# Altium schematic sheet GA-RELE.SchDoc(GA-RELE)
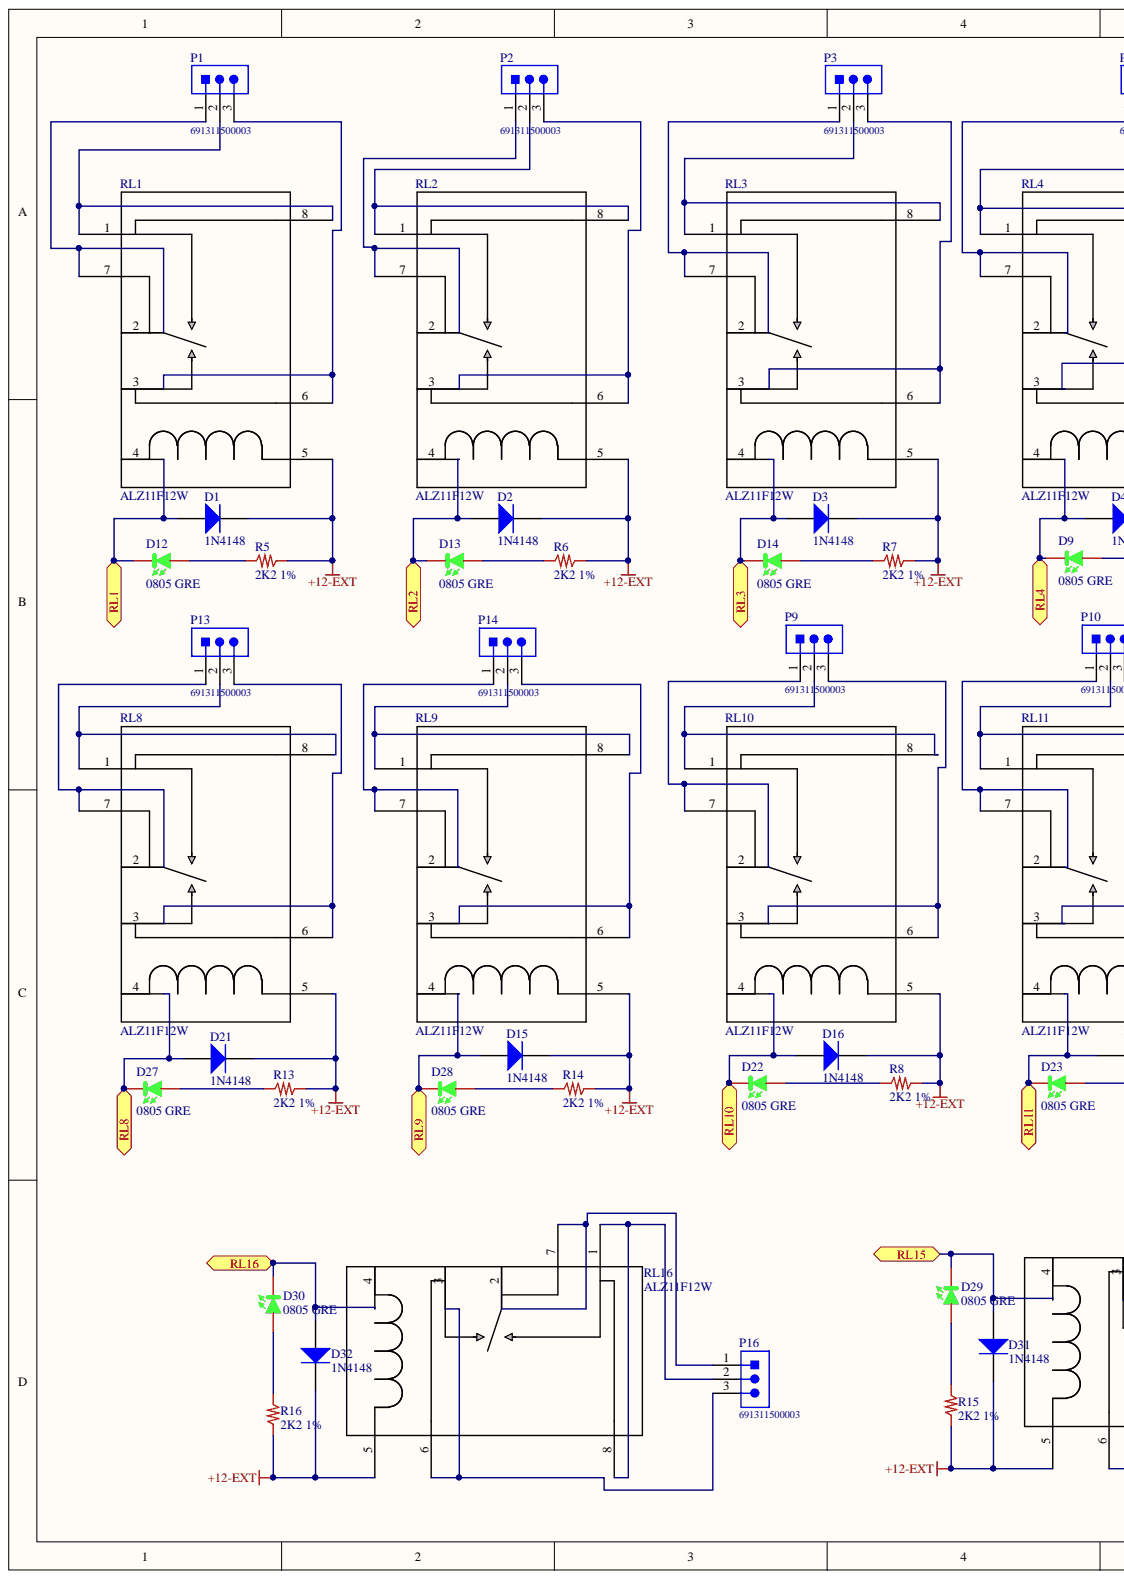
[diagram p0: GA-RELE.SchDoc, left]
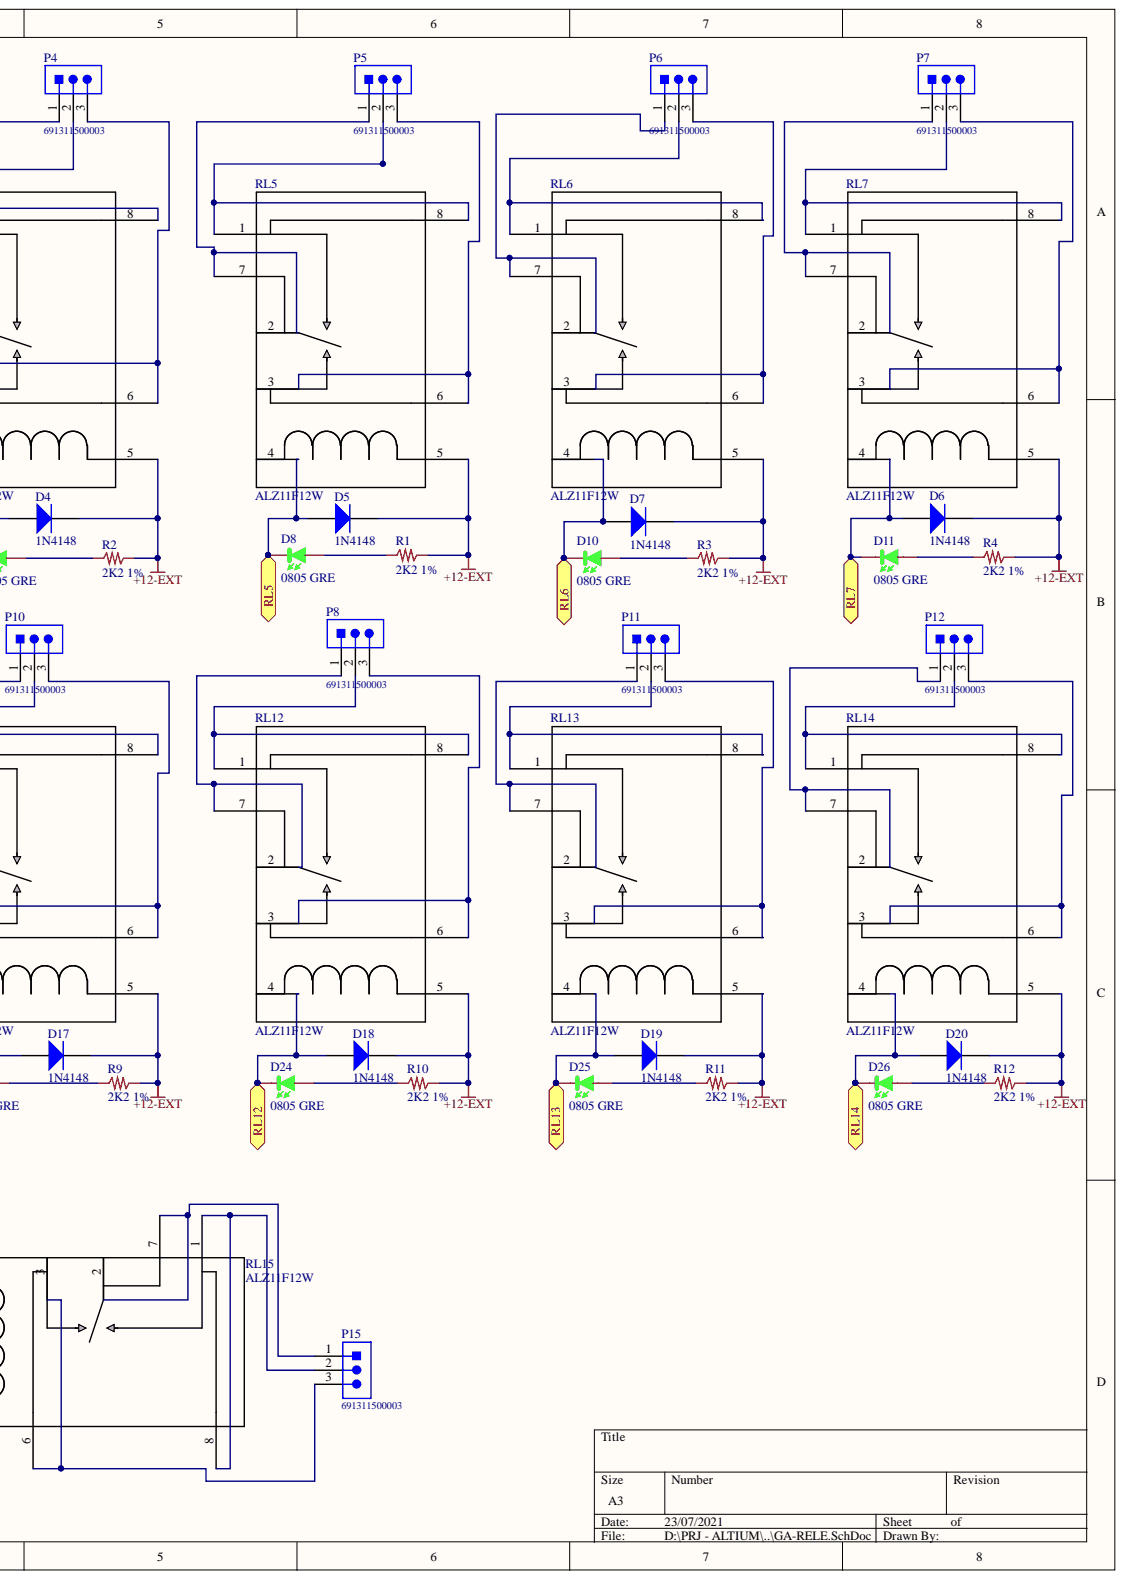
[diagram p1: GA-RELE.SchDoc, right]

## Parts
D1  1N4148  [1N4148]
D2  1N4148  [1N4148]
D3  1N4148  [1N4148]
D4  1N4148  [1N4148]
D5  1N4148  [1N4148]
D6  1N4148  [1N4148]
D7  1N4148  [1N4148]
D8  0805 GRE  [0805_Green]
D9  0805 GRE  [0805_Green]
D10  0805 GRE  [0805_Green]
D11  0805 GRE  [0805_Green]
D12  0805 GRE  [0805_Green]
D13  0805 GRE  [0805_Green]
D14  0805 GRE  [0805_Green]
D15  1N4148  [1N4148]
D16  1N4148  [1N4148]
D17  1N4148  [1N4148]
D18  1N4148  [1N4148]
D19  1N4148  [1N4148]
D20  1N4148  [1N4148]
D21  1N4148  [1N4148]
D22  0805 GRE  [0805_Green]
D23  0805 GRE  [0805_Green]
D24  0805 GRE  [0805_Green]
D25  0805 GRE  [0805_Green]
D26  0805 GRE  [0805_Green]
D27  0805 GRE  [0805_Green]
D28  0805 GRE  [0805_Green]
D29  0805 GRE  [0805_Green]
D30  0805 GRE  [0805_Green]
D31  1N4148  [1N4148]
D32  1N4148  [1N4148]
P1  691311500003  [691311500003]
P2  691311500003  [691311500003]
P3  691311500003  [691311500003]
P4  691311500003  [691311500003]
P5  691311500003  [691311500003]
P6  691311500003  [691311500003]
P7  691311500003  [691311500003]
P8  691311500003  [691311500003]
P9  691311500003  [691311500003]
P10  691311500003  [691311500003]
P11  691311500003  [691311500003]
P12  691311500003  [691311500003]
P13  691311500003  [691311500003]
P14  691311500003  [691311500003]
P15  691311500003  [691311500003]
P16  691311500003  [691311500003]
R1  2K2 1%  [0805_R]
R2  2K2 1%  [0805_R]
R3  2K2 1%  [0805_R]
R4  2K2 1%  [0805_R]
R5  2K2 1%  [0805_R]
R6  2K2 1%  [0805_R]
R7  2K2 1%  [0805_R]
R8  2K2 1%  [0805_R]
R9  2K2 1%  [0805_R]
R10  2K2 1%  [0805_R]
R11  2K2 1%  [0805_R]
R12  2K2 1%  [0805_R]
R13  2K2 1%  [0805_R]
R14  2K2 1%  [0805_R]
R15  2K2 1%  [0805_R]
R16  2K2 1%  [0805_R]
RL1  ALZ11F12W  [PAN_ALZ1]
RL2  ALZ11F12W  [PAN_ALZ1]
RL3  ALZ11F12W  [PAN_ALZ1]
RL4  ALZ11F12W  [PAN_ALZ1]
RL5  ALZ11F12W  [PAN_ALZ1]
RL6  ALZ11F12W  [PAN_ALZ1]
RL7  ALZ11F12W  [PAN_ALZ1]
RL8  ALZ11F12W  [PAN_ALZ1]
RL9  ALZ11F12W  [PAN_ALZ1]
RL10  ALZ11F12W  [PAN_ALZ1]
RL11  ALZ11F12W  [PAN_ALZ1]
RL12  ALZ11F12W  [PAN_ALZ1]
RL13  ALZ11F12W  [PAN_ALZ1]
RL14  ALZ11F12W  [PAN_ALZ1]
RL15  ALZ11F12W  [PAN_ALZ1]
RL16  ALZ11F12W  [PAN_ALZ1]

## Ports
RL1, RL2, RL3, RL4, RL5, RL6, RL7, RL8, RL9, RL10, RL11, RL12, RL13, RL14, RL15, RL16

## Nets
+12-EXT: D1.2 D2.2 D3.2 D4.2 D5.2 D6.2 D7.2 D15.2 D16.2 D17.2 D18.2 D19.2 D20.2 D21.2 D31.2 D32.2 R1.2 R2.2 R3.2 R4.2 R5.2 R6.2 R7.2 R8.2 R9.2 R10.2 R11.2 R12.2 R13.2 R14.2 R15.2 R16.2 RL1.5 RL2.5 RL3.5 RL4.5 RL5.5 RL6.5 RL7.5 RL8.5 RL9.5 RL10.5 RL11.5 RL12.5 RL13.5 RL14.5 RL15.5 RL16.5
RL1: D1.1 D12.K RL1.4 ; port RL1
RL2: D2.1 D13.K RL2.4 ; port RL2
RL3: D3.1 D14.K RL3.4 ; port RL3
RL4: D4.1 D9.K RL4.4 ; port RL4
RL5: D5.1 D8.K RL5.4 ; port RL5
RL6: D7.1 D10.K RL6.4 ; port RL6
RL7: D6.1 D11.K RL7.4 ; port RL7
RL8: D21.1 D27.K RL8.4 ; port RL8
RL9: D15.1 D28.K RL9.4 ; port RL9
RL10: D16.1 D22.K RL10.4 ; port RL10
RL11: D17.1 D23.K RL11.4 ; port RL11
RL12: D18.1 D24.K RL12.4 ; port RL12
RL13: D19.1 D25.K RL13.4 ; port RL13
RL14: D20.1 D26.K RL14.4 ; port RL14
RL15: D29.K D31.1 RL15.4 ; port RL15
RL16: D30.K D32.1 RL16.4 ; port RL16
NetD8_A: D8.A R1.1
NetD9_A: D9.A R2.1
NetD10_A: D10.A R3.1
NetD11_A: D11.A R4.1
NetD12_A: D12.A R5.1
NetD13_A: D13.A R6.1
NetD14_A: D14.A R7.1
NetD22_A: D22.A R8.1
NetD23_A: D23.A R9.1
NetD24_A: D24.A R10.1
NetD25_A: D25.A R11.1
NetD26_A: D26.A R12.1
NetD27_A: D27.A R13.1
NetD28_A: D28.A R14.1
NetD29_A: D29.A R15.1
NetD30_A: D30.A R16.1
NetP1_1: P1.1 RL1.2 RL1.7
NetP1_2: P1.2 RL1.1 RL1.8
NetP1_3: P1.3 RL1.3 RL1.6
NetP2_1: P2.1 RL2.2 RL2.7
NetP2_2: P2.2 RL2.1 RL2.8
NetP2_3: P2.3 RL2.3 RL2.6
NetP3_1: P3.1 RL3.2 RL3.7
NetP3_2: P3.2 RL3.1 RL3.8
NetP3_3: P3.3 RL3.3 RL3.6
NetP4_1: P4.1 RL4.2 RL4.7
NetP4_2: P4.2 RL4.1 RL4.8
NetP4_3: P4.3 RL4.3 RL4.6
NetP5_1: P5.1 RL5.2 RL5.7
NetP5_2: P5.2 RL5.1 RL5.8
NetP5_3: P5.3 RL5.3 RL5.6
NetP6_1: P6.1 RL6.2 RL6.7
NetP6_2: P6.2 RL6.1 RL6.8
NetP6_3: P6.3 RL6.3 RL6.6
NetP7_1: P7.1 RL7.2 RL7.7
NetP7_2: P7.2 RL7.1 RL7.8
NetP7_3: P7.3 RL7.3 RL7.6
NetP8_1: P8.1 RL12.2 RL12.7
NetP8_2: P8.2 RL12.1 RL12.8
NetP8_3: P8.3 RL12.3 RL12.6
NetP9_1: P9.1 RL10.2 RL10.7
NetP9_2: P9.2 RL10.1 RL10.8
NetP9_3: P9.3 RL10.3 RL10.6
NetP10_1: P10.1 RL11.2 RL11.7
NetP10_2: P10.2 RL11.1 RL11.8
NetP10_3: P10.3 RL11.3 RL11.6
NetP11_1: P11.1 RL13.2 RL13.7
NetP11_2: P11.2 RL13.1 RL13.8
NetP11_3: P11.3 RL13.3 RL13.6
NetP12_1: P12.1 RL14.2 RL14.7
NetP12_2: P12.2 RL14.1 RL14.8
NetP12_3: P12.3 RL14.3 RL14.6
NetP13_1: P13.1 RL8.2 RL8.7
NetP13_2: P13.2 RL8.1 RL8.8
NetP13_3: P13.3 RL8.3 RL8.6
NetP14_1: P14.1 RL9.2 RL9.7
NetP14_2: P14.2 RL9.1 RL9.8
NetP14_3: P14.3 RL9.3 RL9.6
NetP15_1: P15.1 RL15.2 RL15.7
NetP15_2: P15.2 RL15.1 RL15.8
NetP15_3: P15.3 RL15.3 RL15.6
NetP16_1: P16.1 RL16.2 RL16.7
NetP16_2: P16.2 RL16.1 RL16.8
NetP16_3: P16.3 RL16.3 RL16.6

## Library data of the parts
D1-D7 D15-D21 D31 D32 = 1N4148: High Conductance Fast Diode; Published: 8-Jun-2000; PackageReference: DO-35; PackageDescription: Diode, Axial; Body 3.8 x 1.9 mm (LxDia. max), Lead Dia. 0.53 mm (max); LatestRevisionNote: Re-released for DXP Platform.; LatestRevisionDate: 17-Jul-2002; DatasheetVersion: 1997; ComponentLink2URL: http://www.fairchildsemi.com/ds/1N%2F1N4148.pdf; ComponentLink2Description: Datasheet; ComponentLink1URL: http://www.fairchildsemi.com/; ComponentLink1Description: Manufacturer Link; Component Kind: Standard; Code_JEDEC: DO-204-AH; Publisher: Altium Limited; Library Name: HSW.SchLib
D8-D14 D22-D30 = LED_Verde_0805: LED Verde 0805; V: 3.1V; Produttore: WE; Luminosità: 450mcd; Cod. Produttore: 150080GS75000; Cod. Interno: LEDS 9AC080GS7500; Component Kind: Standard; Library Name: DiodiLED.SchLib
P1-P16 = 691311500003: Serie 311 - 5.08mm Open Vertical PCB Header WR-TBL, 3 pin; Pin Number: 3; Gender: Male; Published Date: July 2018; Pitch: 5.08 mm; Part Number: 691311500003; Packaging: Box; Operating Temperature: -40 to 105 degC; Mounting Technology: THT; Library Name: Pluggable PCB System WR-TBL_5.08 mm.SchLib; ComponentLink2URL: http://katalog.we-online.de/em/datasheet/6913115000xx.pdf; ComponentLink2Description: Datasheet; ComponentLink1URL: http://www.we-online.de; ComponentLink1Description: Manufacturer; Component Kind: Standard; Publisher: Wurth Elektronik
R1-R16 = 2K2 1%: Res Film Spesso 0805 1/2W 2K2 1%; Cod. Interno: ??; Library Name: ResSMD0805.SchLib; Component Kind: Standard
RL1-RL16 = ALZ11F12W: RELE PANASONIC 16A 277VAC -1 FORM-C; Built_By: EMA_UL_Team; Vendor: Panasonic; Value: Value; Type: DEV; RefDes: RefDes; Manufacturer_Part_Number: ALZ11F12; Library Name: RELE-16A-ALZ.SchLib; Component Kind: Standard; Copyright: Copyright (C) 2018 Accelerated Designs. All rights reserved
